# Altium schematic sheet stm32jsk2.SchDoc(stm32jsk2)
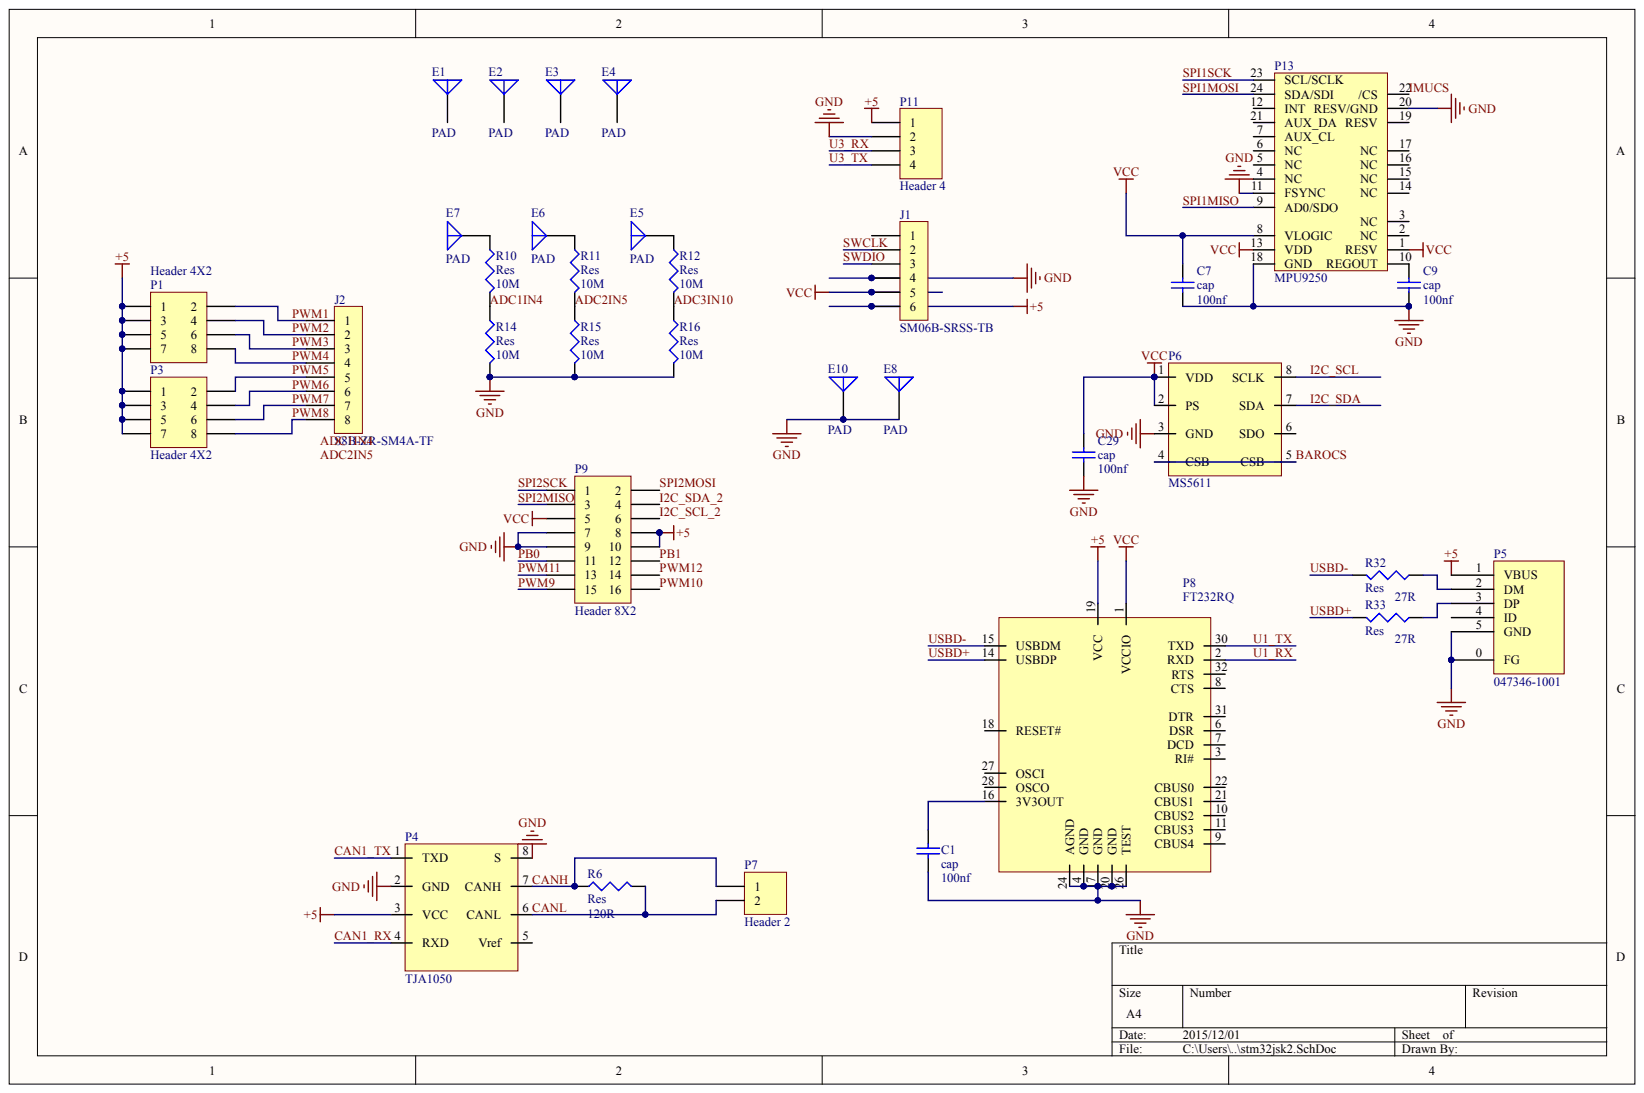
[diagram p0: stm32jsk2.SchDoc]

## Parts
C1  cap  value 100nf
C7  cap  value 100nf
C9  cap  value 100nf
C29  cap  value 100nf
E1  PAD
E2  PAD
E3  PAD
E4  PAD
E5  PAD
E6  PAD
E7  PAD
E8  PAD
E10  PAD
J1  SM06B-SRSS-TB
J2  S8B-ZR-SM4A-TF
P1  Header 4X2
P3  Header 4X2
P4  TJA1050
P5  047346-1001
P6  MS5611
P7  Header 2
P8  FT232RQ
P9  Header 8X2
P11  Header 4
P13  MPU9250
R6  Res  value 120R
R10  Res  value 10M
R11  Res  value 10M
R12  Res  value 10M
R14  Res  value 10M
R15  Res  value 10M
R16  Res  value 10M
R32  Res  value 27R
R33  Res  value 27R

## Nets
+5: J1.6 P1.1 P1.3 P1.5 P1.7 P3.1 P3.3 P3.5 P3.7 P4.3(VCC) P5.1(VBUS) P8.19(VCC) P9.8 P9.10 P11.1
GND: C1.1 C7.1 C9.1 C29.1 E8.1 E10.1 J1.4 P4.2(GND) P4.8(S) P5.0(FG) P5.5(GND) P6.3(GND) P8.4(GND) P8.17(GND) P8.20(GND) P8.24(AGND) P8.26(TEST) P9.7 P9.9 P11.2 P13.11(FSYNC) P13.18(GND) P13.20(RESV/GND) R14.2 R15.2 R16.2
VCC: C7.2 C29.2 J1.5 P6.1(VDD) P6.2(PS) P8.1(VCCIO) P9.5 P13.1(RESV) P13.8(VLOGIC) P13.13(VDD)
ADC1IN4: R10.2 R14.1
ADC2IN5: R11.2 R15.1
ADC3IN10: R12.2 R16.1
BAROCS: P6.4(CSB) P6.5(CSB)
CAN1_RX: P4.4(RXD)
CAN1_TX: P4.1(TXD)
CANH: P4.7(CANH) P7.1 R6.1
CANL: P4.6(CANL) P7.2 R6.2
I2C_SCL: P6.8(SCLK)
I2C_SCL_2: P9.6
I2C_SDA: P6.7(SDA)
I2C_SDA_2: P9.4
IMUCS: P13.22(/CS)
PB0: P9.11
PB1: P9.12
PWM1: J2.1 P1.2
PWM2: J2.2 P1.4
PWM3: J2.3 P1.6
PWM4: J2.4 P1.8
PWM5: J2.5 P3.2
PWM6: J2.6 P3.4
PWM7: J2.7 P3.6
PWM8: J2.8 P3.8
PWM9: P9.15
PWM10: P9.16
PWM11: P9.13
PWM12: P9.14
SPI1MISO: P13.9(AD0/SDO)
SPI1MOSI: P13.24(SDA/SDI)
SPI1SCK: P13.23(SCL/SCLK)
SPI2MISO: P9.3
SPI2MOSI: P9.2
SPI2SCK: P9.1
SWCLK: J1.2
SWDIO: J1.3
U1_RX: P8.2(RXD)
U1_TX: P8.30(TXD)
U3_RX: P11.3
U3_TX: P11.4
USBD+: P8.14(USBDP) R33.2
USBD-: P8.15(USBDM) R32.2
NetC1_2: C1.2 P8.16(3V3OUT)
NetC9_2: C9.2 P13.10(REGOUT)
NetE5_1: E5.1 R12.1
NetE6_1: E6.1 R11.1
NetE7_1: E7.1 R10.1
NetP5_2: P5.2(DM) R32.1
NetP5_3: P5.3(DP) R33.1

Not connected: E1 1; E2 1; E3 1; E4 1; J1 1; P4 5(Vref); P5 4(ID); P6 6(SDO); P8 3(RI#) 6(DSR) 7(DCD) 8(CTS) 9(CBUS4) 10(CBUS2) 11(CBUS3) 18(RESET#) 21(CBUS1) 22(CBUS0) 27(OSCI) 28(OSCO) 31(DTR) 32(RTS); P13 2(NC) 3(NC) 4(NC) 5(NC) 6(NC) 7(AUX_CL) 12(INT) 14(NC) 15(NC) 16(NC) 17(NC) 19(RESV) 21(AUX_DA)

## Library data of the parts
J1 J2 = (no symbol name): Manufacturer: JST; Assembly: P; Supplier: JST
  J1: PN: SM06B-SRSS-TB
  J2: PN: S8B-ZR-SM4A-TF
P5 = (no symbol name): Manufacturer: Molex; Assembly: P; PN: 047346-1001
C1 C7 C9 C29 E1-E8 E10 P1 P3 P4 P6-P9 P11 P13 R6 R10-R12 R14-R16 R32 R33 = (no symbol name)
  C1 C7 C9 C29: Published: 8-Jun-2000; LatestRevisionDate: 29-May-2009; LatestRevisionNote: IPC-7351 Footprint Added.; PackageReference: C1206; PackageDescription: Chip Capacitor
  E1-E8 E10: Published: 24-Mar-1999; LatestRevisionDate: 17-Jul-2002; LatestRevisionNote: Re-released for DXP Platform.; PackageReference: PIN1
  P1 P3 P7 P9 P11: LatestRevisionDate: 17-Jul-2002; LatestRevisionNote: Re-released for DXP Platform.
  R6 R10-R12 R14-R16 R32 R33: Published: 8-Jun-2000; LatestRevisionDate: 29-May-2009; LatestRevisionNote: IPC-7351 Footprint Added.; PackageReference: J1-0603; PackageDescription: Chip Resistor
  C1 C7 C9 C29 E1-E8 E10 P1 P3 P7 P9 P11 R6 R10-R12 R14-R16 R32 R33: Publisher: Altium Limited
